# Altium schematic sheet MCU.SchDoc
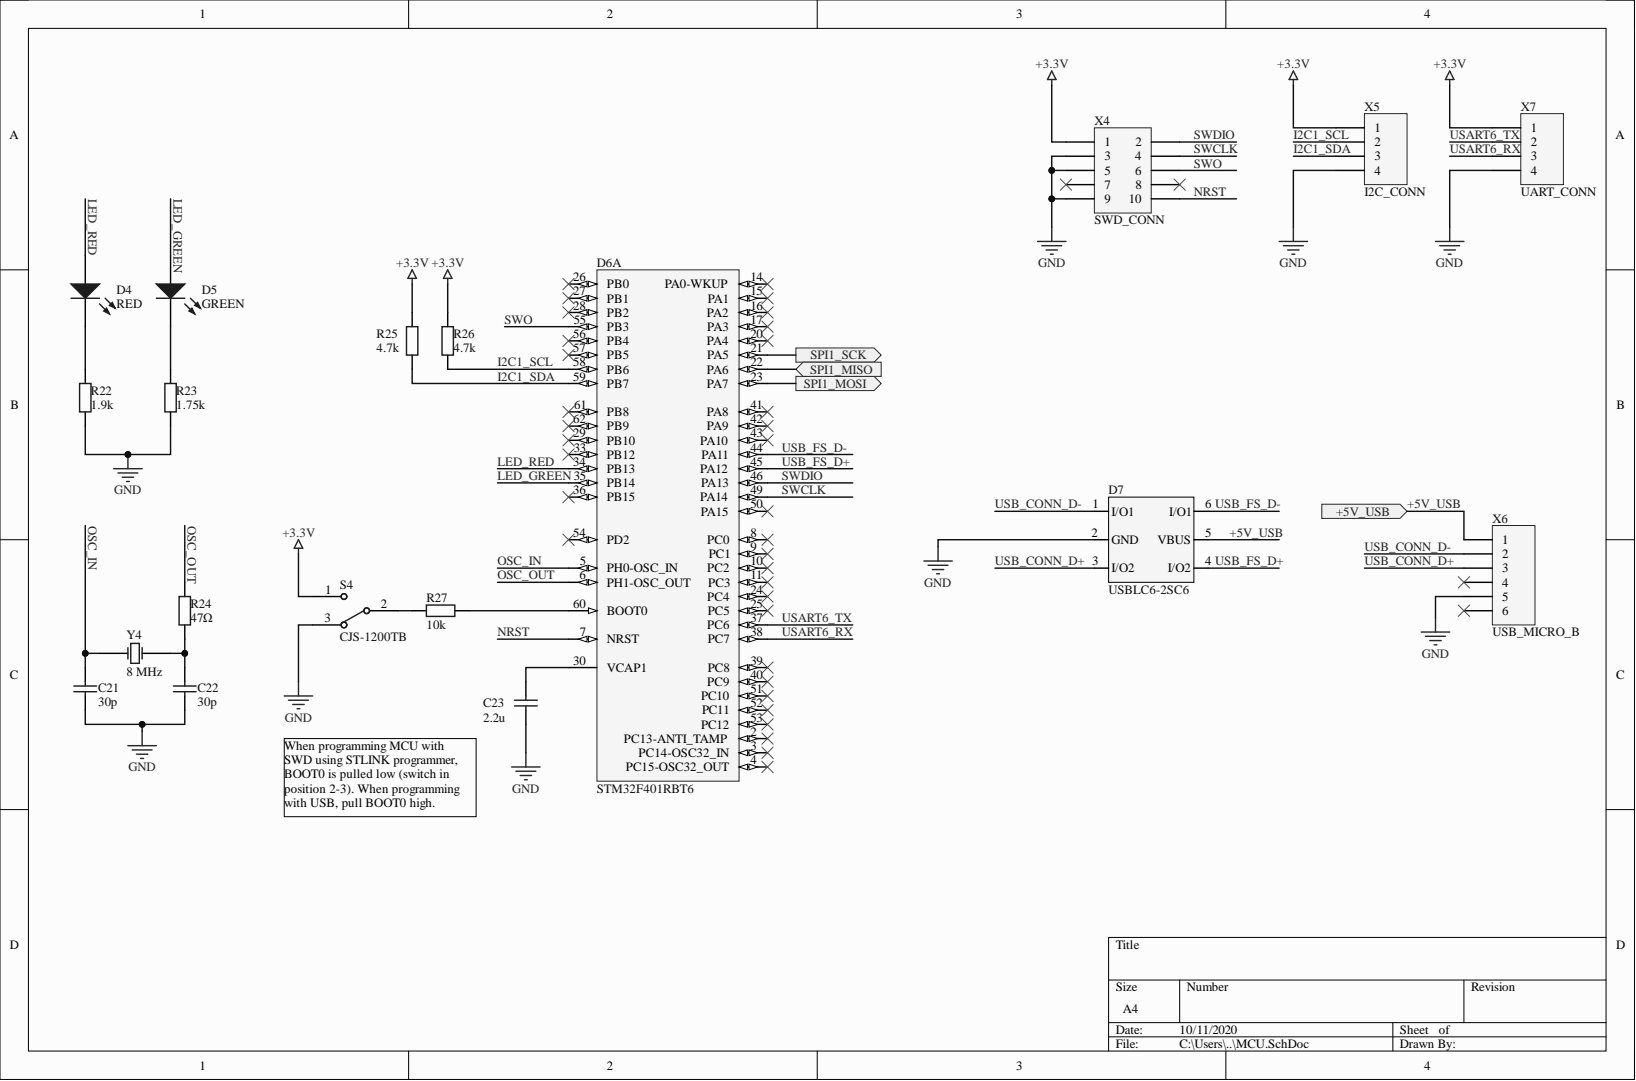
[diagram p0: MCU.SchDoc]

## Parts
C21  30p
C22  30p
C23  2.2u
D4  RED
D5  GREEN
D6  STM32F401RBT6  (part A)
D7  USBLC6-2SC6
R22  1.9k
R23  1.75k
R24  47Ω
R25  4.7k
R26  4.7k
R27  10k
S4  CJS-1200TB
X4  SWD_CONN
X5  I2C_CONN
X6  USB_MICRO_B
X7  UART_CONN
Y4  8 MHz

## Ports
+5V_USB, SPI1_MISO, SPI1_MOSI, SPI1_SCK

## Nets
+3.3V: R25.2 R26.2 S4.1 X4.1 X5.1 X7.1
GND: C21.1 C22.1 C23.1 D7.2(GND) R22.1 R23.1 S4.3 X4.3 X4.5 X4.9 X5.4 X6.5 X7.4
+5V_USB: D7.5(VBUS) X6.1 ; port +5V_USB
I2C1_SCL: D6.58(PB6) R26.1 X5.2
I2C1_SDA: D6.59(PB7) R25.1 X5.3
LED_GREEN: D5.1 D6.35(PB14)
LED_RED: D4.1 D6.34(PB13)
NRST: D6.7(NRST) X4.10
OSC_IN: C21.2 D6.5(PH0-OSC_IN) Y4.1
OSC_OUT: D6.6(PH1-OSC_OUT) R24.2
SPI1_MISO: D6.22(PA6) ; port SPI1_MISO
SPI1_MOSI: D6.23(PA7) ; port SPI1_MOSI
SPI1_SCK: D6.21(PA5) ; port SPI1_SCK
SWCLK: D6.49(PA14) X4.4
SWDIO: D6.46(PA13) X4.2
SWO: D6.55(PB3) X4.6
USART6_RX: D6.38(PC7) X7.3
USART6_TX: D6.37(PC6) X7.2
USB_CONN_D+: D7.3(I/O2) X6.3
USB_CONN_D-: D7.1(I/O1) X6.2
USB_FS_D+: D6.45(PA12) D7.4(I/O2)
USB_FS_D-: D6.44(PA11) D7.6(I/O1)
NetC22_2: C22.2 R24.1 Y4.2
NetC23_2: C23.2 D6.30(VCAP1)
NetD4_2: D4.2 R22.2
NetD5_2: D5.2 R23.2
NetD6_60: D6.60(BOOT0) R27.1
NetR27_2: R27.2 S4.2

Not connected: D6 2(PC13-ANTI_TAMP) 3(PC14-OSC32_IN) 4(PC15-OSC32_OUT) 8(PC0) 9(PC1) 10(PC2) 11(PC3) 14(PA0-WKUP) 15(PA1) 16(PA2) 17(PA3) 20(PA4) 24(PC4) 25(PC5) 26(PB0) 27(PB1) 28(PB2) 29(PB10) 33(PB12) 36(PB15) 39(PC8) 40(PC9) 41(PA8) 42(PA9) 43(PA10) 50(PA15) 51(PC10) 52(PC11) 53(PC12) 54(PD2) 56(PB4) 57(PB5) 61(PB8) 62(PB9); X4 7 8; X6 4 6

## Library data of the parts
C21-C23 = Cap: Component Kind: Standard; Library Name: Miscellaneous Devices.IntLib; Signal Integrity: Cap; Simulation: CAP
D4 D5 = LED1: Component Kind: Standard; Library Name: Miscellaneous Devices.IntLib; Simulation: LED1
D7 = USBLC6: Component Kind: Standard; Library Name: Custom_Lib.SchLib
R22-R26 = Res2: Component Kind: Standard; Library Name: Miscellaneous Devices.IntLib; Signal Integrity: Res; Simulation: RESISTOR
R27 = Res2: Manufacturer 1: Yageo; Manufacturer Part Number 1: RC0603FR-0710KL; Component Kind: Standard; Library Name: Miscellaneous Devices.IntLib; MOUSER Part Number: 603-RC0603FR-0710KL; Signal Integrity: Res; Simulation: RESISTOR
S4 = SW-SPDT: Component Kind: Standard; Library Name: Miscellaneous Devices.IntLib
X4 = Header 5X2: Component Kind: Standard; Library Name: Miscellaneous Connectors.IntLib; Signal Integrity: Connector
X5 X7 = Header 4: Component Kind: Standard; Library Name: Miscellaneous Connectors.IntLib; Signal Integrity: Connector
X6 = Header 6: Component Kind: Standard; Library Name: Miscellaneous Connectors.IntLib; Signal Integrity: Connector
Y4 = XTAL: Component Kind: Standard; Library Name: Miscellaneous Devices.IntLib; Simulation: XTAL
D6 = STM32F401RBT6: Component Kind: Standard; Library Name: STMicroelectronics STM32 F4.IntLib
